# Altium schematic sheet MCU.SchDoc
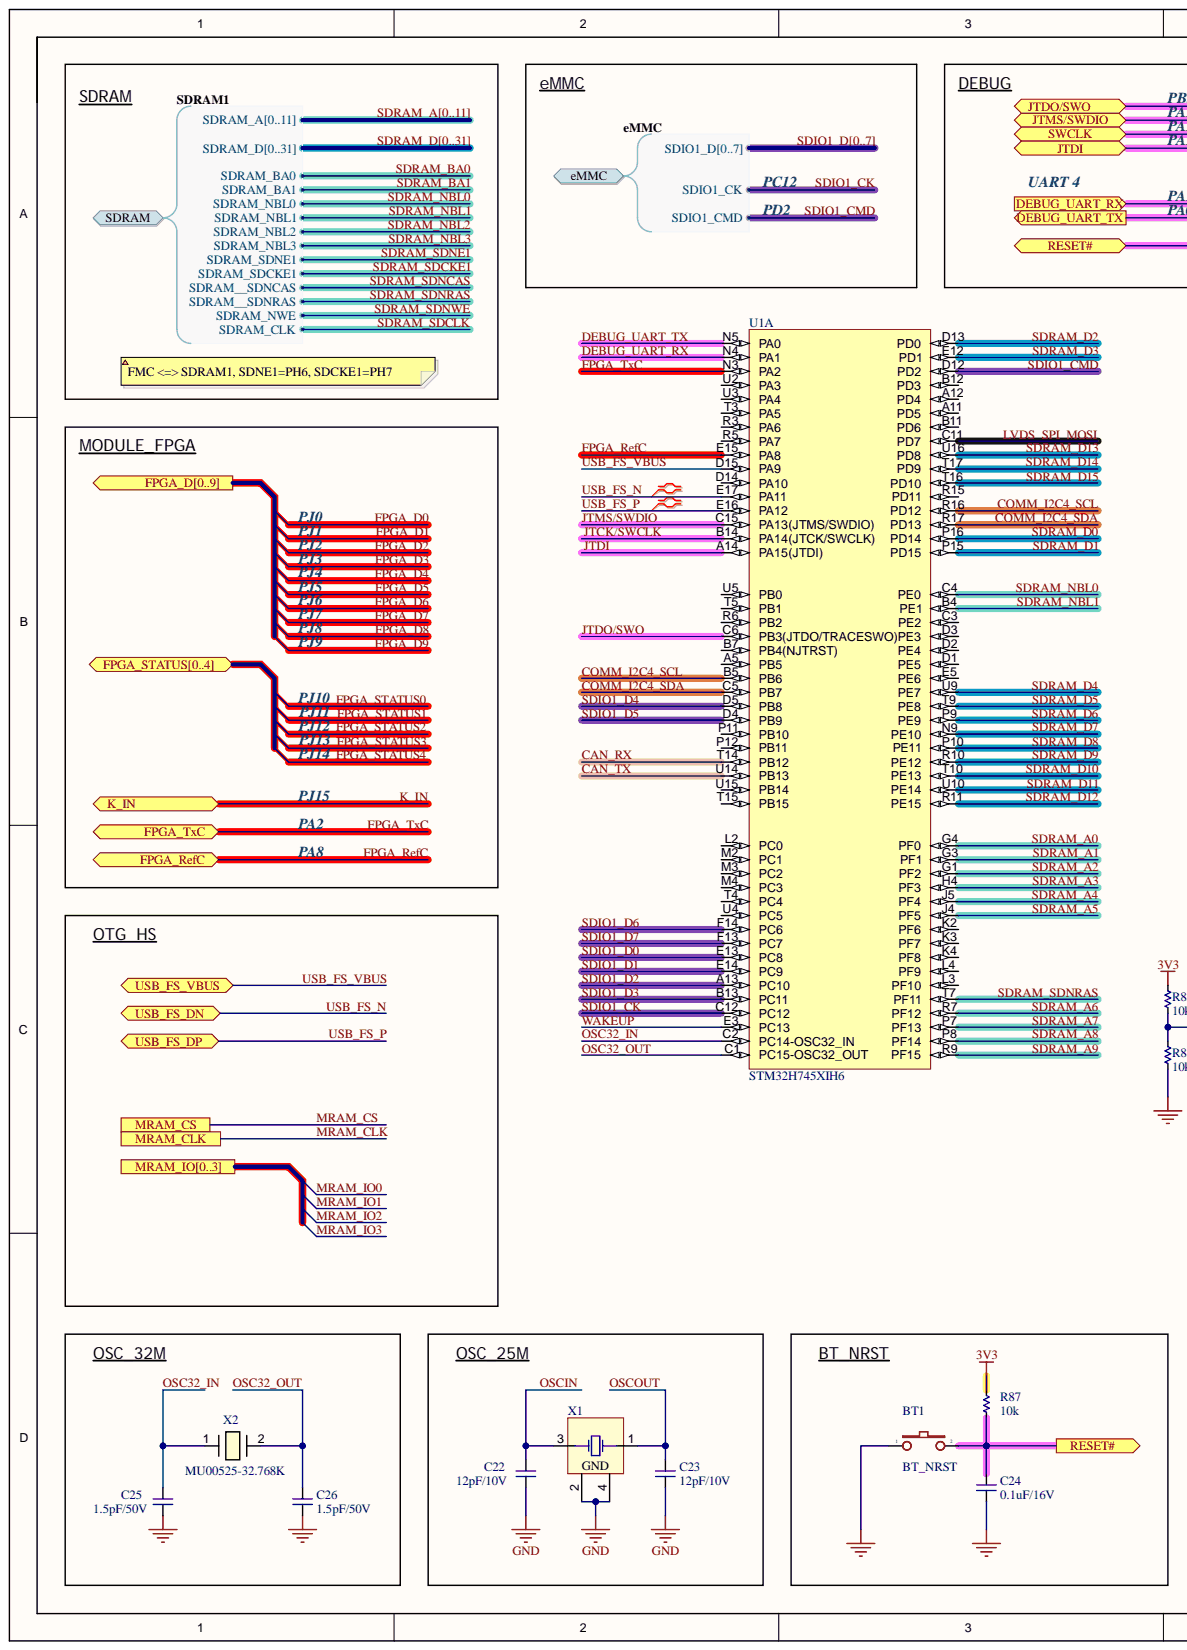
[diagram p0: MCU.SchDoc, left]
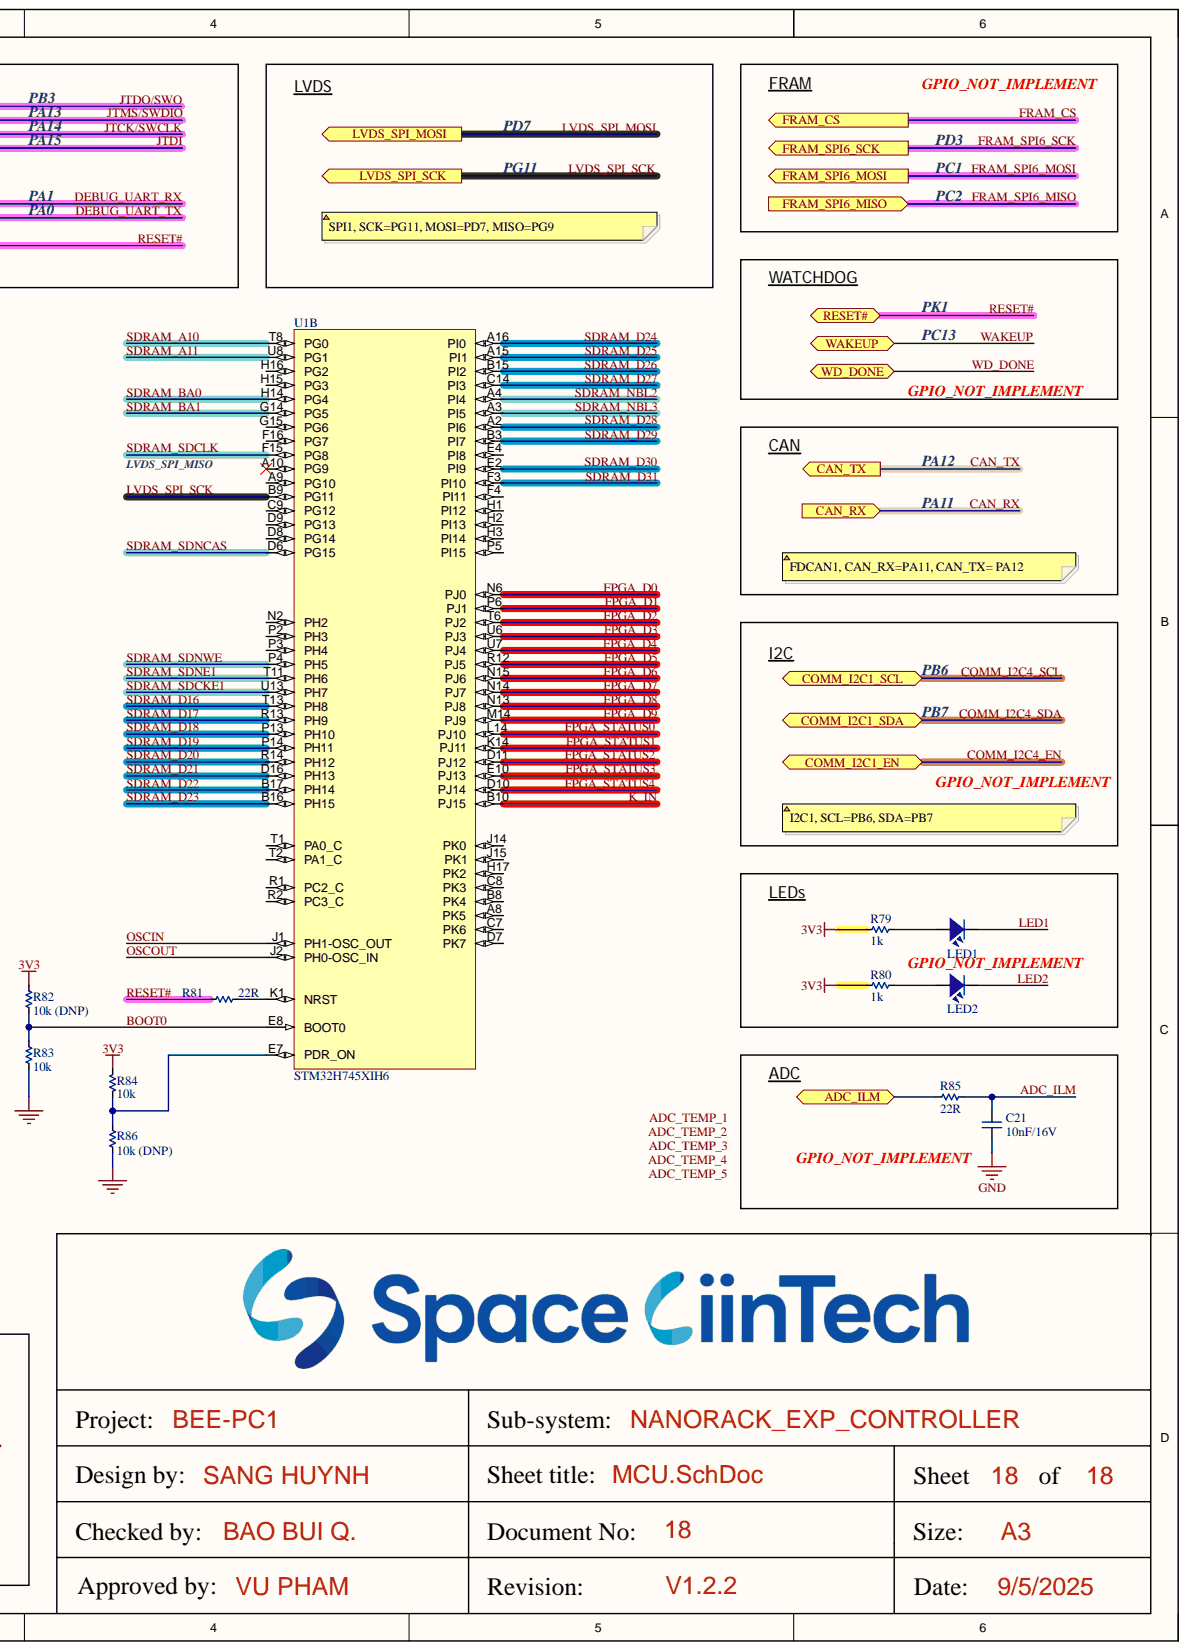
[diagram p1: MCU.SchDoc, right]

## Parts
BT1  BT_NRST  [SW2-SMD]
C21  10nF/16V  [CAP_C_1608X80]
C22  12pF/10V  [CAP_C_1608X80]
C23  12pF/10V  [CAP_C_1608X80]
C24  0.1uF/16V  [CAP_C_1608X80]
C25  1.5pF/50V  [CAP_C_1608X80]
C26  1.5pF/50V  [CAP_C_1608X80]
LED1  LED  [LED_0603]
LED2  LED  [LED_0603]
R79  1k  [RES_C_1608X60]
R80  1k  [RES_C_1608X60]
R81  22R  [RES_C_1608X60]
R82  10k (DNP)  [RES_C_1608X60]
R83  10k  [RES_C_1608X60]
R84  10k  [RES_C_1608X60]
R85  22R  [RES_C_1608X60]
R86  10k (DNP)  [RES_C_1608X60]
R87  10k  [RES_C_1608X60]
U1  STM32H745XIH6  [BGA265C80P17X17_1400X1400X110N]  (parts A,B)
X1  XT4  [XTAL_ECS-250-12-33Q-JES-TR]  (value not drawn)
X2  MU00525-32.768K  [XTAL_MU00525_NDK]

## Ports
ADC_ILM, CAN_RX, CAN_TX, COMM_I2C1_EN, COMM_I2C1_SCL, COMM_I2C1_SDA, DEBUG_UART_RX, DEBUG_UART_TX, eMMC, eMMC.SDIO1_CK, eMMC.SDIO1_CMD, eMMC.SDIO1_D0, eMMC.SDIO1_D1, eMMC.SDIO1_D2, eMMC.SDIO1_D3, eMMC.SDIO1_D4, eMMC.SDIO1_D5, eMMC.SDIO1_D6, eMMC.SDIO1_D7, eMMC.SDIO1_D[0..7], FPGA_D0, FPGA_D1, FPGA_D2, FPGA_D3, FPGA_D4, FPGA_D5, FPGA_D6, FPGA_D7, FPGA_D8, FPGA_D9, FPGA_D[0..9], FPGA_RefC, FPGA_STATUS0, FPGA_STATUS1, FPGA_STATUS2, FPGA_STATUS3, FPGA_STATUS4, FPGA_STATUS[0..4], FPGA_TxC, FRAM_CS, FRAM_SPI6_MISO, FRAM_SPI6_MOSI, FRAM_SPI6_SCK, JTDI, JTDO/SWO, JTMS/SWDIO, K_IN, LVDS_SPI_MOSI, LVDS_SPI_SCK, MRAM_CLK, MRAM_CS, MRAM_IO0, MRAM_IO1, MRAM_IO2, MRAM_IO3, MRAM_IO[0..3], RESET#, SDRAM, SDRAM.SDRAM__SDNCAS, SDRAM.SDRAM__SDNRAS, SDRAM.SDRAM_A0, SDRAM.SDRAM_A1, SDRAM.SDRAM_A2, SDRAM.SDRAM_A3, SDRAM.SDRAM_A4, SDRAM.SDRAM_A5, SDRAM.SDRAM_A6, SDRAM.SDRAM_A7, SDRAM.SDRAM_A8, SDRAM.SDRAM_A9, SDRAM.SDRAM_A10, SDRAM.SDRAM_A11, SDRAM.SDRAM_A[0..11], SDRAM.SDRAM_BA0, SDRAM.SDRAM_BA1, SDRAM.SDRAM_CLK, SDRAM.SDRAM_D0, SDRAM.SDRAM_D1, SDRAM.SDRAM_D2, SDRAM.SDRAM_D3, SDRAM.SDRAM_D4, SDRAM.SDRAM_D5, SDRAM.SDRAM_D6, SDRAM.SDRAM_D7, SDRAM.SDRAM_D8, SDRAM.SDRAM_D9, SDRAM.SDRAM_D10, SDRAM.SDRAM_D11, SDRAM.SDRAM_D12, SDRAM.SDRAM_D13, SDRAM.SDRAM_D14, SDRAM.SDRAM_D15, SDRAM.SDRAM_D16, SDRAM.SDRAM_D17, SDRAM.SDRAM_D18, SDRAM.SDRAM_D19, SDRAM.SDRAM_D20, SDRAM.SDRAM_D21, SDRAM.SDRAM_D22, SDRAM.SDRAM_D23, SDRAM.SDRAM_D24, SDRAM.SDRAM_D25, SDRAM.SDRAM_D26, SDRAM.SDRAM_D27, SDRAM.SDRAM_D28, SDRAM.SDRAM_D29, SDRAM.SDRAM_D30, SDRAM.SDRAM_D31, SDRAM.SDRAM_D[0..31], SDRAM.SDRAM_NBL0, SDRAM.SDRAM_NBL1, SDRAM.SDRAM_NBL2, SDRAM.SDRAM_NBL3, SDRAM.SDRAM_NWE, SDRAM.SDRAM_SDCKE1, SDRAM.SDRAM_SDNE1, SWCLK, USB_FS_DN, USB_FS_DP, USB_FS_VBUS, WAKEUP, WD_DONE

## Nets
3V3: R79.1 R80.1 R82.1 R84.1 R87.1
GND: BT1.1 C21.1 C22.1 C23.1 C24.1 C25.1 C26.1 R83.2 R86.2 X1.2 X1.4
ADC_ILM: C21.2 R85.2
BOOT0: R82.2 R83.1 U1.E8(BOOT0)
CAN_RX: U1.T14(PB12) ; port CAN_RX
CAN_TX: U1.U14(PB13) ; port CAN_TX
COMM_I2C4_SCL: U1.B5(PB6) U1.R16(PD12) ; port COMM_I2C1_SCL
COMM_I2C4_SDA: U1.C5(PB7) U1.R17(PD13) ; port COMM_I2C1_SDA
DEBUG_UART_RX: U1.N4(PA1) ; port DEBUG_UART_RX
DEBUG_UART_TX: U1.N5(PA0) ; port DEBUG_UART_TX
FPGA_D0: U1.N6(PJ0) ; port FPGA_D[0..9]  [also labelled ]
FPGA_D1: U1.P6(PJ1) ; port FPGA_D[0..9]  [also labelled ]
FPGA_D2: U1.T6(PJ2) ; port FPGA_D[0..9]  [also labelled ]
FPGA_D3: U1.U6(PJ3) ; port FPGA_D[0..9]  [also labelled ]
FPGA_D4: U1.U7(PJ4) ; port FPGA_D[0..9]  [also labelled ]
FPGA_D5: U1.R12(PJ5) ; port FPGA_D[0..9]  [also labelled ]
FPGA_D6: U1.N15(PJ6) ; port FPGA_D[0..9]  [also labelled ]
FPGA_D7: U1.N14(PJ7) ; port FPGA_D[0..9]  [also labelled ]
FPGA_D8: U1.N13(PJ8) ; port FPGA_D[0..9]  [also labelled ]
FPGA_D9: U1.M14(PJ9) ; port FPGA_D[0..9]  [also labelled ]
FPGA_RefC: U1.E15(PA8) ; port FPGA_RefC
FPGA_STATUS0: U1.L14(PJ10) ; port FPGA_STATUS[0..4]  [also labelled ]
FPGA_STATUS1: U1.K14(PJ11) ; port FPGA_STATUS[0..4]  [also labelled ]
FPGA_STATUS2: U1.D11(PJ12) ; port FPGA_STATUS[0..4]  [also labelled ]
FPGA_STATUS3: U1.E10(PJ13) ; port FPGA_STATUS[0..4]  [also labelled ]
FPGA_STATUS4: U1.D10(PJ14) ; port FPGA_STATUS[0..4]  [also labelled ]
FPGA_TxC: U1.N3(PA2) ; port FPGA_TxC
JTCK/SWCLK: U1.B14(PA14(JTCK/SWCLK)) ; port SWCLK
JTDI: U1.A14(PA15(JTDI)) ; port JTDI
JTDO/SWO: U1.C6(PB3(JTDO/TRACESWO)) ; port JTDO/SWO
JTMS/SWDIO: U1.C15(PA13(JTMS/SWDIO)) ; port JTMS/SWDIO
K_IN: U1.B10(PJ15) ; port K_IN
LED1: LED1.2
LED2: LED2.2
LVDS_SPI_MOSI: U1.C11(PD7) ; port LVDS_SPI_MOSI
LVDS_SPI_SCK: U1.B9(PG11) ; port LVDS_SPI_SCK
OSC32_IN: C25.2 U1.C2(PC14-OSC32_IN) X2.1
OSC32_OUT: C26.2 U1.C1(PC15-OSC32_OUT) X2.2
OSCIN: C22.2 U1.J1(PH1-OSC_OUT) X1.3
OSCOUT: C23.2 U1.J2(PH0-OSC_IN) X1.1
RESET#: BT1.2 C24.2 R81.1 R87.2 ; port RESET#
SDIO1_CK: U1.C12(PC12) ; port eMMC
SDIO1_CMD: U1.D12(PD2) ; port eMMC
SDIO1_D0: U1.E13(PC8) ; port eMMC  [also labelled SDIO1_D]
SDIO1_D1: U1.E14(PC9) ; port eMMC  [also labelled SDIO1_D]
SDIO1_D2: U1.A13(PC10) ; port eMMC  [also labelled SDIO1_D]
SDIO1_D3: U1.B13(PC11) ; port eMMC  [also labelled SDIO1_D]
SDIO1_D4: U1.D5(PB8) ; port eMMC  [also labelled SDIO1_D]
SDIO1_D5: U1.D4(PB9) ; port eMMC  [also labelled SDIO1_D]
SDIO1_D6: U1.F14(PC6) ; port eMMC  [also labelled SDIO1_D]
SDIO1_D7: U1.F13(PC7) ; port eMMC  [also labelled SDIO1_D]
SDRAM_A0: U1.G4(PF0) ; port SDRAM  [also labelled SDRAM_A]
SDRAM_A1: U1.G3(PF1) ; port SDRAM  [also labelled SDRAM_A]
SDRAM_A2: U1.G1(PF2) ; port SDRAM  [also labelled SDRAM_A]
SDRAM_A3: U1.H4(PF3) ; port SDRAM  [also labelled SDRAM_A]
SDRAM_A4: U1.J5(PF4) ; port SDRAM  [also labelled SDRAM_A]
SDRAM_A5: U1.J4(PF5) ; port SDRAM  [also labelled SDRAM_A]
SDRAM_A6: U1.R7(PF12) ; port SDRAM  [also labelled SDRAM_A]
SDRAM_A7: U1.P7(PF13) ; port SDRAM  [also labelled SDRAM_A]
SDRAM_A8: U1.P8(PF14) ; port SDRAM  [also labelled SDRAM_A]
SDRAM_A9: U1.R9(PF15) ; port SDRAM  [also labelled SDRAM_A]
SDRAM_A10: U1.T8(PG0) ; port SDRAM  [also labelled SDRAM_A]
SDRAM_A11: U1.U8(PG1) ; port SDRAM  [also labelled SDRAM_A]
SDRAM_BA0: U1.H14(PG4) ; port SDRAM
SDRAM_BA1: U1.G14(PG5) ; port SDRAM
SDRAM_D0: U1.P16(PD14) ; port SDRAM  [also labelled SDRAM_D]
SDRAM_D1: U1.P15(PD15) ; port SDRAM  [also labelled SDRAM_D]
SDRAM_D2: U1.D13(PD0) ; port SDRAM  [also labelled SDRAM_D]
SDRAM_D3: U1.E12(PD1) ; port SDRAM  [also labelled SDRAM_D]
SDRAM_D4: U1.U9(PE7) ; port SDRAM  [also labelled SDRAM_D]
SDRAM_D5: U1.T9(PE8) ; port SDRAM  [also labelled SDRAM_D]
SDRAM_D6: U1.P9(PE9) ; port SDRAM  [also labelled SDRAM_D]
SDRAM_D7: U1.N9(PE10) ; port SDRAM  [also labelled SDRAM_D]
SDRAM_D8: U1.P10(PE11) ; port SDRAM  [also labelled SDRAM_D]
SDRAM_D9: U1.R10(PE12) ; port SDRAM  [also labelled SDRAM_D]
SDRAM_D10: U1.T10(PE13) ; port SDRAM  [also labelled SDRAM_D]
SDRAM_D11: U1.U10(PE14) ; port SDRAM  [also labelled SDRAM_D]
SDRAM_D12: U1.R11(PE15) ; port SDRAM  [also labelled SDRAM_D]
SDRAM_D13: U1.U16(PD8) ; port SDRAM  [also labelled SDRAM_D]
SDRAM_D14: U1.T17(PD9) ; port SDRAM  [also labelled SDRAM_D]
SDRAM_D15: U1.T16(PD10) ; port SDRAM  [also labelled SDRAM_D]
SDRAM_D16: U1.T13(PH8) ; port SDRAM  [also labelled SDRAM_D]
SDRAM_D17: U1.R13(PH9) ; port SDRAM  [also labelled SDRAM_D]
SDRAM_D18: U1.P13(PH10) ; port SDRAM  [also labelled SDRAM_D]
SDRAM_D19: U1.P14(PH11) ; port SDRAM  [also labelled SDRAM_D]
SDRAM_D20: U1.R14(PH12) ; port SDRAM  [also labelled SDRAM_D]
SDRAM_D21: U1.D16(PH13) ; port SDRAM  [also labelled SDRAM_D]
SDRAM_D22: U1.B17(PH14) ; port SDRAM  [also labelled SDRAM_D]
SDRAM_D23: U1.B16(PH15) ; port SDRAM  [also labelled SDRAM_D]
SDRAM_D24: U1.A16(PI0) ; port SDRAM  [also labelled SDRAM_D]
SDRAM_D25: U1.A15(PI1) ; port SDRAM  [also labelled SDRAM_D]
SDRAM_D26: U1.B15(PI2) ; port SDRAM  [also labelled SDRAM_D]
SDRAM_D27: U1.C14(PI3) ; port SDRAM  [also labelled SDRAM_D]
SDRAM_D28: U1.A2(PI6) ; port SDRAM  [also labelled SDRAM_D]
SDRAM_D29: U1.B3(PI7) ; port SDRAM  [also labelled SDRAM_D]
SDRAM_D30: U1.E2(PI9) ; port SDRAM  [also labelled SDRAM_D]
SDRAM_D31: U1.F3(PI10) ; port SDRAM  [also labelled SDRAM_D]
SDRAM_NBL0: U1.C4(PE0) ; port SDRAM
SDRAM_NBL1: U1.B4(PE1) ; port SDRAM
SDRAM_NBL2: U1.A4(PI4) ; port SDRAM
SDRAM_NBL3: U1.A3(PI5) ; port SDRAM
SDRAM_SDCKE1: U1.U13(PH7) ; port SDRAM
SDRAM_SDCLK: U1.F15(PG8) ; port SDRAM
SDRAM_SDNCAS: U1.D6(PG15) ; port SDRAM
SDRAM_SDNE1: U1.T11(PH6) ; port SDRAM
SDRAM_SDNRAS: U1.T7(PF11) ; port SDRAM
SDRAM_SDNWE: U1.P4(PH5) ; port SDRAM
USB_FS_N: U1.E17(PA11) ; port USB_FS_DN
USB_FS_P: U1.E16(PA12) ; port USB_FS_DP
USB_FS_VBUS: U1.D15(PA9) ; port USB_FS_VBUS
WAKEUP: U1.E3(PC13) ; port WAKEUP
NetLED1_1: LED1.1 R79.2
NetLED2_1: LED2.1 R80.2
NetR81_2: R81.2 U1.K1(NRST)
NetR84_2: R84.2 R86.1 U1.E7(PDR_ON)
NetR85_1: R85.1 ; port ADC_ILM
ADC_TEMP_1:
ADC_TEMP_2:
ADC_TEMP_3:
ADC_TEMP_4:
ADC_TEMP_5:
COMM_I2C4_EN: ; port COMM_I2C1_EN
FRAM_CS: ; port FRAM_CS
FRAM_SPI6_MISO: ; port FRAM_SPI6_MISO
FRAM_SPI6_MOSI: ; port FRAM_SPI6_MOSI
FRAM_SPI6_SCK: ; port FRAM_SPI6_SCK
MRAM_CLK: ; port MRAM_CLK
MRAM_CS: ; port MRAM_CS
MRAM_IO0: ; port MRAM_IO[0..3]  [also labelled ]
MRAM_IO1: ; port MRAM_IO[0..3]  [also labelled ]
MRAM_IO2: ; port MRAM_IO[0..3]  [also labelled ]
MRAM_IO3: ; port MRAM_IO[0..3]  [also labelled ]
WD_DONE: ; port WD_DONE

Not connected: U1 A5(PB5) A8(PK5) A9(PG10) A10(PG9) A11(PD5) A12(PD4) B7(PB4(NJTRST)) B8(PK4) B11(PD6) B12(PD3) C3(PE2) C7(PK6) C8(PK3) C9(PG12) D1(PE5) D2(PE4) D3(PE3) D7(PK7) D8(PG14) D9(PG13) D14(PA10) E4(PI8) E5(PE6) F4(PI11) F16(PG7) G15(PG6) H1(PI12) H2(PI13) H3(PI14) H15(PG3) H16(PG2) H17(PK2) J14(PK0) J15(PK1) K2(PF6) K3(PF7) K4(PF8) L2(PC0) L3(PF10) L4(PF9) M2(PC1) M3(PC2) M4(PC3) N2(PH2) P2(PH3) P3(PH4) P5(PI15) P11(PB10) P12(PB11) R1(PC2_C) R2(PC3_C) R3(PA6) R5(PA7) R6(PB2) R15(PD11) T1(PA0_C) T2(PA1_C) T3(PA5) T4(PC4) T5(PB1) T15(PB15) U2(PA3) U3(PA4) U4(PC5) U5(PB0) U15(PB14)

## Library data of the parts
BT1 = BT2: Switch button 2 pin; Value: SW chip 2 pin; Component Kind: Standard; Library Name: COMM_SCHLIB.SchLib
C21-C26 = CAP_SMD: CAP_Chip; Value: 33pF; Component Kind: Standard; Library Name: LABLIB.SchLib
LED1 LED2 = LED SMD: Value: LED 3 - 5 ly 2 Colour; Component Kind: Standard; Library Name: COMM_SCHLIB.SchLib
R79-R87 = _RES_B_2: RES; Component Kind: Standard; Library Name: LABLIB.SchLib
U1 = STM32H745XIH6: High-performance and DSP with DP-FPU, Arm Cortex-M7 + Cortex-M4 MCU with 2MBytes of Flash memory, 1MB RAM, 480 MHz CPU, Art Accelerator, L1 cache, external memory interface, large set of peripherals, SMPS; Manufacturer_Name: STMicroelectronics; Component Kind: Standard; Datasheet: https://www.st.com/resource/en/datasheet/stm32h745zg.pdf; Manufacturer_Part_Number: STM32H745XIH6; RefDes: RefDes; Type: Type; Copyright: Copyright (C) 2025 Ultra Librarian. All rights reserved.; Library Name: COMM_SCHLIB.SchLib
X1 = XT4: Crystal, 16 MHz, -30 to 85 degC, 3.2 x 2.5 x 0.9 mm SMD; Value: 4Mhz; Component Kind: Standard; Library Name: COMM_SCHLIB.SchLib
X2 = MU00525-32.768K: 32.768 kHz ±20ppm Crystal 12.5pF 70 kOhms 2-SMD, No Lead; Manufacturer_Name: NDK; Component Kind: Standard; Manufacturer_Part_Number: MU00525-32.768K; Type: Crystals; Copyright: Copyright (C) 2025 Ultra Librarian. All rights reserved.; Library Name: COMM_SCHLIB.SchLib
